# Altium schematic sheet Stabilizer_Piezo_Driver.SchDoc(Stabilizer_Piezo_Driver)
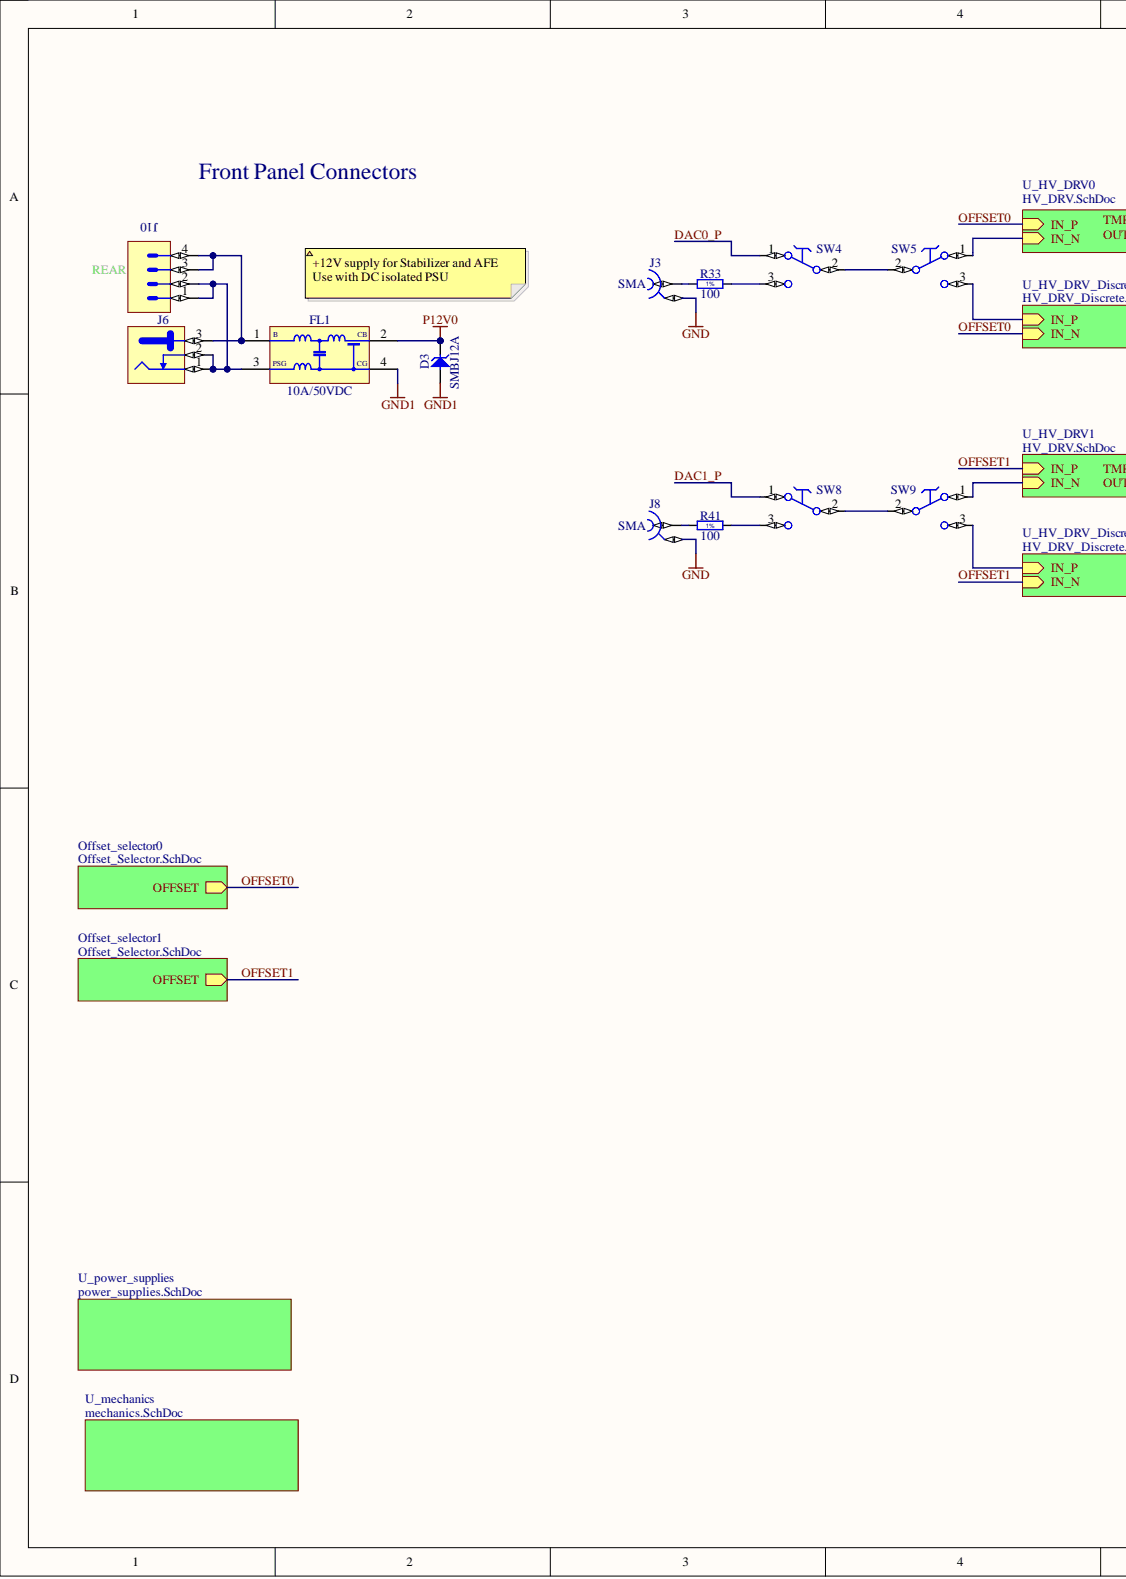
[diagram p0: Stabilizer_Piezo_Driver.SchDoc, left]
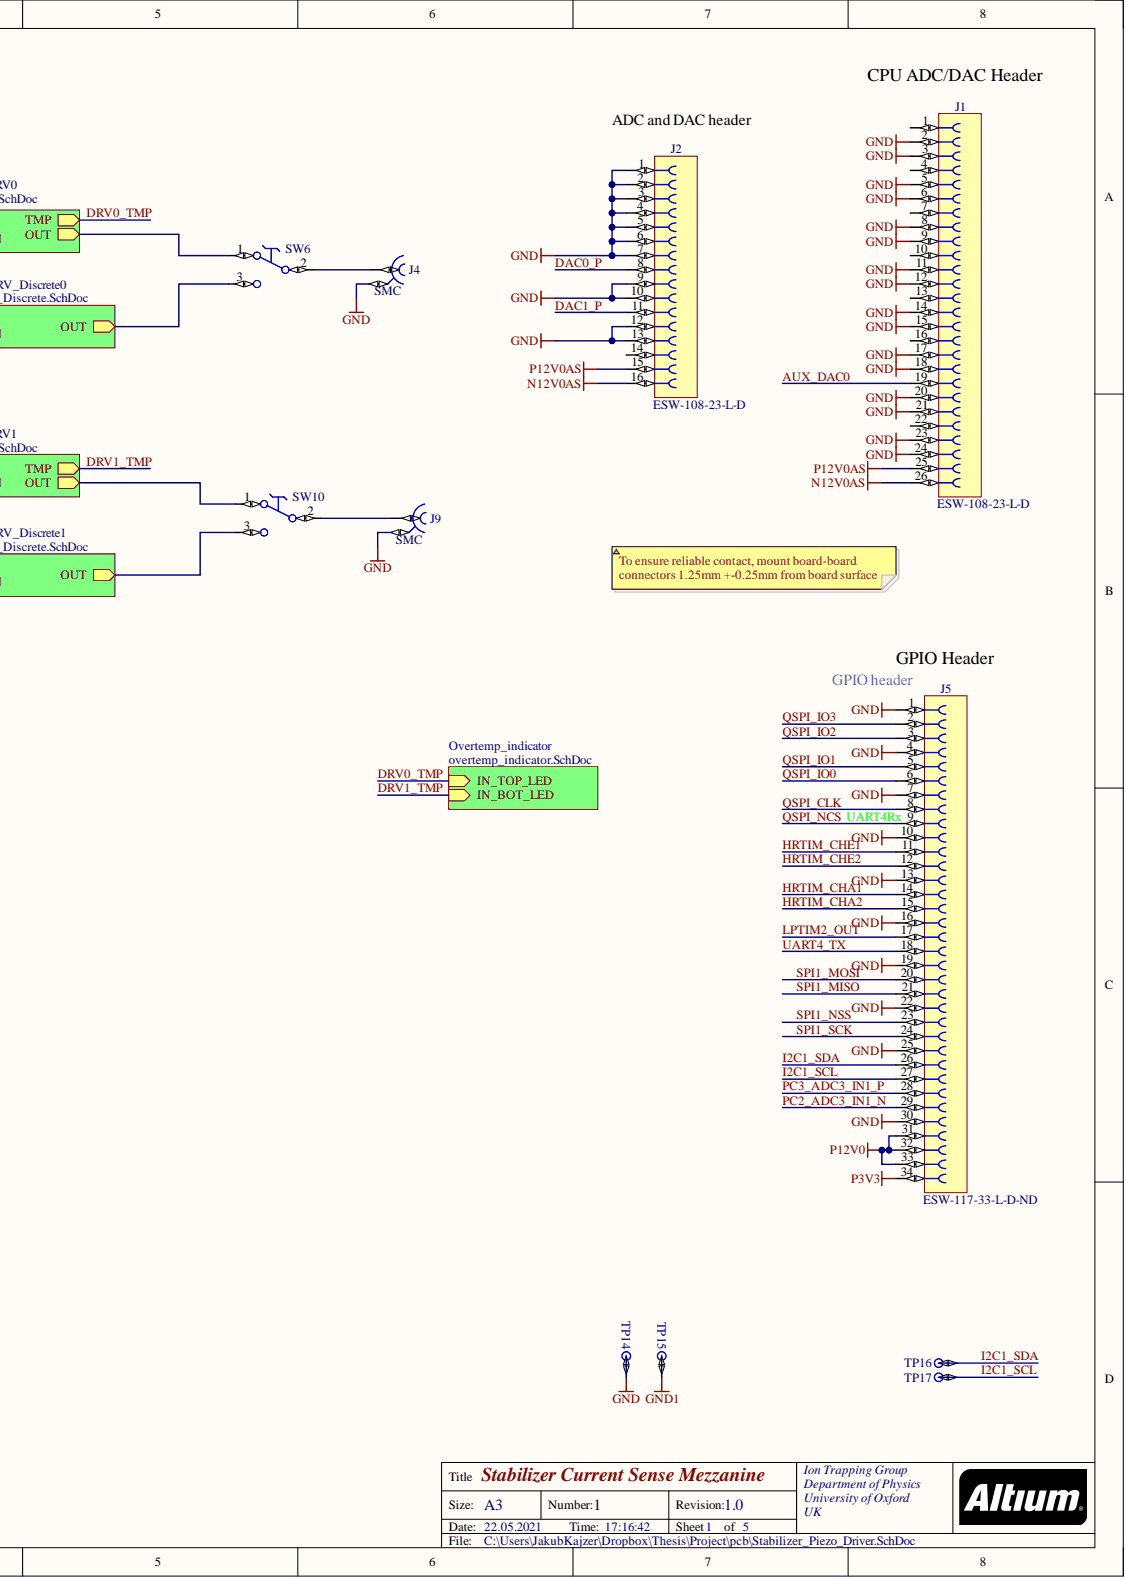
[diagram p1: Stabilizer_Piezo_Driver.SchDoc, right]

## Parts
D3  SMBJ12A  [DIOM5336X265N]
FL1  10A/50VDC  [FIL_MURATA_BNX02]
J1  ESW-108-23-L-D  [ESW-113-23-L-D]
J2  ESW-108-23-L-D  [ESW-108-23-L-D]
J3  SMA  [RF249BT0050GHDW]
J4  SMC  [JOHNSON_131-6701-341]
J5  ESW-108-23-L-D  Manufacturer Part Number: ESW-117-33-L-D-ND  [ESW-117-23-L-D]
J6  Jack  [SWITCHCRAFT_L712RA]  (value not drawn)
J8  SMA  [RF249BT0050GHDW]
J9  SMC  [JOHNSON_131-6701-341]
J10  Mini-Fit Jr.™  [MOLEX_39-30-0040]  (value not drawn)
R33  100  [RESC1005X40N]
R41  100  [RESC1005X40N]
SW4  Switch  [SW_C&K_1101M2S3CQE2]  (value not drawn)
SW5  Switch  [SW_C&K_1101M2S3CQE2]  (value not drawn)
SW6  Switch  [SW_C&K_1101M2S3CQE2]  (value not drawn)
SW8  Switch  [SW_C&K_1101M2S3CQE2]  (value not drawn)
SW9  Switch  [SW_C&K_1101M2S3CQE2]  (value not drawn)
SW10  Switch  [SW_C&K_1101M2S3CQE2]  (value not drawn)
TP14  Test Point  [TPS127]  (value not drawn)  (no BOM)
TP15  Test Point  [TPS127]  (value not drawn)  (no BOM)
TP16  Test Point  [TPS127]  (value not drawn)  (no BOM)
TP17  Test Point  [TPS127]  (value not drawn)  (no BOM)

## Nets
GND: J1.2 J1.3 J1.5 J1.6 J1.8 J1.9 J1.11 J1.12 J1.14 J1.15 J1.17 J1.18 J1.20 J1.21 J1.23 J1.24 J2.1 J2.2 J2.3 J2.4 J2.5 J2.6 J2.7 J2.9 J2.10 J2.12 J2.13 J3.2 J4.2 J5.1 J5.4 J5.7 J5.10 J5.13 J5.16 J5.19 J5.22 J5.25 J5.30 J8.2 J9.2 TP14.1
GND1: D3.2 FL1.4 TP15.1
N12V0AS: J1.26 J2.16
P3V3: J5.34
P12V0: D3.1 FL1.2 J5.31 J5.32 J5.33
P12V0AS: J1.25 J2.15
AUX_DAC0: J1.19
DAC0_P: J2.8 SW4.1
DAC1_P: J2.11 SW8.1
HRTIM_CHA1: J5.14
HRTIM_CHA2: J5.15
HRTIM_CHE1: J5.11
HRTIM_CHE2: J5.12
I2C1_SCL: J5.27 TP17.1
I2C1_SDA: J5.26 TP16.1
LPTIM2_OUT: J5.17
PC2_ADC3_IN1_N: J5.29
PC3_ADC3_IN1_P: J5.28
QSPI_CLK: J5.8
QSPI_IO0: J5.6
QSPI_IO1: J5.5
QSPI_IO2: J5.3
QSPI_IO3: J5.2
QSPI_NCS: J5.9
SPI1_MISO: J5.21
SPI1_MOSI: J5.20
SPI1_NSS: J5.23
SPI1_SCK: J5.24
UART4_TX: J5.18
NetFL1_1: FL1.1 J6.3 J10.3 J10.4
NetFL1_3: FL1.3 J6.1 J6.2 J10.1 J10.2
NetJ1_1: J1.1
NetJ1_4: J1.4
NetJ1_7: J1.7
NetJ1_10: J1.10
NetJ1_13: J1.13
NetJ1_16: J1.16
NetJ1_22: J1.22
NetJ2_14: J2.14
NetJ3_1: J3.1 R33.1
NetJ4_1: J4.1 SW6.2
NetJ8_1: J8.1 R41.1
NetJ9_1: J9.1 SW10.2
NetR33_2: R33.2 SW4.3
NetR41_2: R41.2 SW8.3
NetSW4_2: SW4.2 SW5.2
NetSW5_1: SW5.1
NetSW5_3: SW5.3
NetSW6_1: SW6.1
NetSW6_3: SW6.3
NetSW8_2: SW8.2 SW9.2
NetSW9_1: SW9.1
NetSW9_3: SW9.3
NetSW10_1: SW10.1
NetSW10_3: SW10.3
DRV0_TMP:
DRV1_TMP:
OFFSET0:
OFFSET1:

## Library data of the parts
D3 = SMBJ12A: Zener Diode; Manufacturer: FAIRCHILD SEMICONDUCTOR; Part Description: Uni-Directional Transient Voltage Suppressor Diode; Bonding: No; Database Table Name: Diodes; Power: 600W; PressFit: No; Voltage: 12V; Case: DO-214AA; Component Kind: Standard; Component Type: Standard; ComponentHeight: 2.65mm; CreateDate: 10/04/2013; Device: SMBJ12A; HelpURL: \\cern.ch\dfs\Applications\Altium\Datasheets\SMBJ.pdf; LatestRevisionDate: 10/04/2013; Library Name: ICs And Semiconductors.DbLib; Manufacturer Part Number: SMBJ12A; Mounted: Yes; PackageDescription: DO-214-AA/SMB Diode, Molded Body; 5.34mm L X 3.625mm W X 2.65mm H, IPC Medium Density; Part Number: SMBJ12A; Pin Count: 2; Sense: No; SMD: Yes; Socket: No; Author: CERN DEM JLC
FL1 = FIL_MURATA_BNX022-01L: Block Type EMIFIL (LC Combined); Manufacturer: MURATA; Part Description: Block Type EMIFIL (LC Combined) SMD Type, BNX02* Series; Database Table Name: Inductors SMD; Resistance: 0.5R; Component Kind: Standard; Component Type: Standard; ComponentHeight: 3.3mm; CreateDate: 10/10/2012; HelpURL: \\cern.ch\dfs\Applications\Altium\Datasheets\FIL_MURATA_BNX022-01L.pdf; LatestRevisionDate: 10/10/2012; Library Name: Inductors & Transformers.DbLib; Manufacturer Part Number: BNX022-01L; Mounted: Yes; Part Number: FIL_MURATA_BNX022-01L; Pin Count: 2; Sense: No; SMD: Yes; Socket: No; Status: None; Value: 10A/50VDC; Author: CERN DEM JLC
J1 = ESW-113-23-L-D: Connector Elevated Socket 26 POS 2.54mm, 13.59mm height; Manufacturer: Samtec; Component Kind: Standard; Library Name: connectors.SchLib; Manufacturer Part Number: ESW-108-23-L-D
J2 = ESW-108-23-L-D: Connector Elevated Socket 16 POS 2.54mm, 13.59mm height; Manufacturer: Samtec; Component Kind: Standard; Library Name: connectors.SchLib; Manufacturer Part Number: ESW-108-23-L-D
J3 J8 = (no symbol name): 50 Ohm Through Hole SMA Right Angle PCB Jack; Manufacturer: AdamTech; Part Number: AdamTech_RF249BT0050GHDW; PressFit: No; Component Kind: Standard; Component Type: Standard; ComponentHeight: 10.44mm; CreateDate: 06.10.2016; Family: SMA; HelpURL: \\cern.ch\dfs\Applications\Altium\Datasheets\AMPHENOL_132203.pdf; LatestRevisionDate: 06.10.2016; Library Name: LIBRARY_SCH.SCHLIB; Manufacturer Part Number: RF249BT0050GHDW; Mounted: Yes; Part Description: 50 Ohm Through Hole SMA Right Angle PCB Jack; Pin Count: 5; Sense: No; SMD: No; Socket: No; Status: None; Author: GK
J4 J9 = JOHNSON_131-6701-341: Part Description: SMC 50 Ohms Right Angle Bulkhead Jack Receptacle; Database Table Name: Miscellaneous; PressFit: No; Component Kind: Standard; Component Type: Standard; ComponentHeight: 7.67mm; CreateDate: 16.02.2010; Family: SMC; HelpURL: \\cern.ch\dfs\Applications\Altium\Datasheets\JOHNSON_131-6701-341.pdf; LatestRevisionDate: 12.01.2017; Library Name: Connectors.DbLib; Manufacturer: JOHNSON; Manufacturer Part Number: 131-6701-341; Mounted: Yes; Part Number: JOHNSON_131-6701-341; Pin Count: 2; Sense: No; SMD: No; Socket: No; Status: None; Author: CERN DEM JLC
J5 = ESW-117-23-L-D: Connector Elevated Socket 34 POS 2.54mm, 13.59mm height; Manufacturer: Samtec; Component Kind: Standard; Library Name: connectors.SchLib
J6 = SWITCHCRAFT_L712RA: 24V /3. 5A, 2.1mm Diameter Pin, SMD DC Power Supply Connector With  Break Contact; Manufacturer Part Number: L712RA; Part Description: 12V/5A, 2.5mm Diameter Pin, THT DC Power Supply Connector With  Break Contact; PressFit: No; Component Kind: Standard; Component Type: Standard; ComponentHeight: 11mm; CreateDate: 15.02.2011; Family: Jack; HelpURL: \\cern.ch\dfs\Applications\Altium\Datasheets\LUMBERG_161314.pdf; LatestRevisionDate: 20.07.2011; Library Name: LIBRARY_SCH.SCHLIB; Manufacturer: SWITCHCRAFT; Mounted: Yes; Part Number: SWITCHCRAFT_L712RA; Pin Count: 3; Sense: No; SMD: No; Socket: No; Status: None; Author: GK
J10 = MOLEX_39-30-0040: Connector 4 Male; Part Description: 4.20mm (.165") Pitch Mini-Fit Jr. Header, Dual Row, Right Angle with Snap-in Plastic Peg PCB Lock, 4 Circuits; Database Table Name: MOLEX; PressFit: No; Component Kind: Standard; Component Type: Standard; ComponentHeight: 11.4mm; CreateDate: 02/10/2018; Family: Mini-Fit Jr.™; HelpURL: \\cern.ch\dfs\Applications\Altium\Datasheets\MOLEX_39-30-0040.pdf; LatestRevisionDate: 02/10/2018; Library Name: Connectors.DbLib; Manufacturer: MOLEX; Manufacturer Part Number: 39-30-0040; Mounted: Yes; Part Number: MOLEX_39-30-0040; Pin Count: 4; Sense: No; SMD: No; Socket: No; Status: None; Author: CERN DEM JLC
R33 R41 = R0402_100R_1%_0.0625W_100PPM: Resistor - 1%; Manufacturer: GENERIC; Manufacturer1 Part Number: 232270671001L; Part Description: General Purpose Thick Film Chip Resistor; Database Table Name: Resistors SMD; PressFit: No; TC: ±100ppm/°C; Case: 0402; Component Kind: Standard; Component Type: Standard; CreateDate: 03.12.2007; HelpURL: \\cern.ch\dfs\Applications\Altium\Datasheets\R0402_Phycomp_RC0402.pdf; LatestRevisionDate: 17.10.2012; Library Name: Resistors.DbLib; Manufacturer1 ComponentHeight: 0.4mm; Manufacturer1 Example: YAGEO PHYCOMP; Manufacturer Part Number: R0402_100R_1%_0.0625W_100PPM; Mounted: Yes; Part Number: R0402_100R_1%_0.0625W_100PPM; Pin Count: 2; Power: 0.0625W; Sense: No; SMD: Yes; Socket: No; Status: Not Recommended; Tolerance: ±1%; Value: 100; Author: CERN DEM JLC
SW4-SW6 SW8-SW10 = SW_C&K_1101M2S3CQE2: SPDT Switch; Manufacturer: C&K; Part Description: PC Thru-Hole SPDT Slide Switch, .200" High Actuator, C&K 1000 Series; Database Table Name: Switches; PressFit: No; Sense Comment: Non-washable; Component Kind: Standard; Component Type: Standard; ComponentHeight: 13.34mm; CreateDate: 30.01.2019; Family: Switch; HelpURL: \\cern.ch\dfs\Applications\Altium\Datasheets\SW_C&K_1101M2S3CQE2.pdf; LatestRevisionDate: 30.01.2019; Library Name: Eletro-mechanical.DbLib; Manufacturer Part Number: 1101M2S3CQE2; Mounted: Yes; PackageDescription: PC Thru-Hole SPDT Slide Switch, .200" High Actuator, C&K 1000 Series; Part Number: SW_C&K_1101M2S3CQE2; Pin Count: 3; Sense: Yes; SMD: No; Socket: No; Status: None; Author: CERN DEM BC
TP14-TP17 = SMD_PAD_1.27X1.27MM: Part Description: 1.27mm x 1.27mm PCB Copper Area (SMD Test Point); Database Table Name: Pads; Mounted: No; Component Type: Standard (No BOM); ComponentHeight: 0mm; CreateDate: 12/01/2008; Description: Test Point; Family: Test Point; HelpURL: Undefined; LatestRevisionDate: 12/01/2008; Library Name: Eletro-mechanical.DbLib; PackageDescription: 1.27mm x 1.27mm PCB Copper Area (SMD Test Point); Part Number: SMD_PAD_1.27X1.27MM; Pin Count: 1; Sense: No; SMD: Yes; Socket: No; Status: None; Author: CERN DEM JLC
